# Altium schematic sheet MCU_PWR.SchDoc(MCU_PWR)
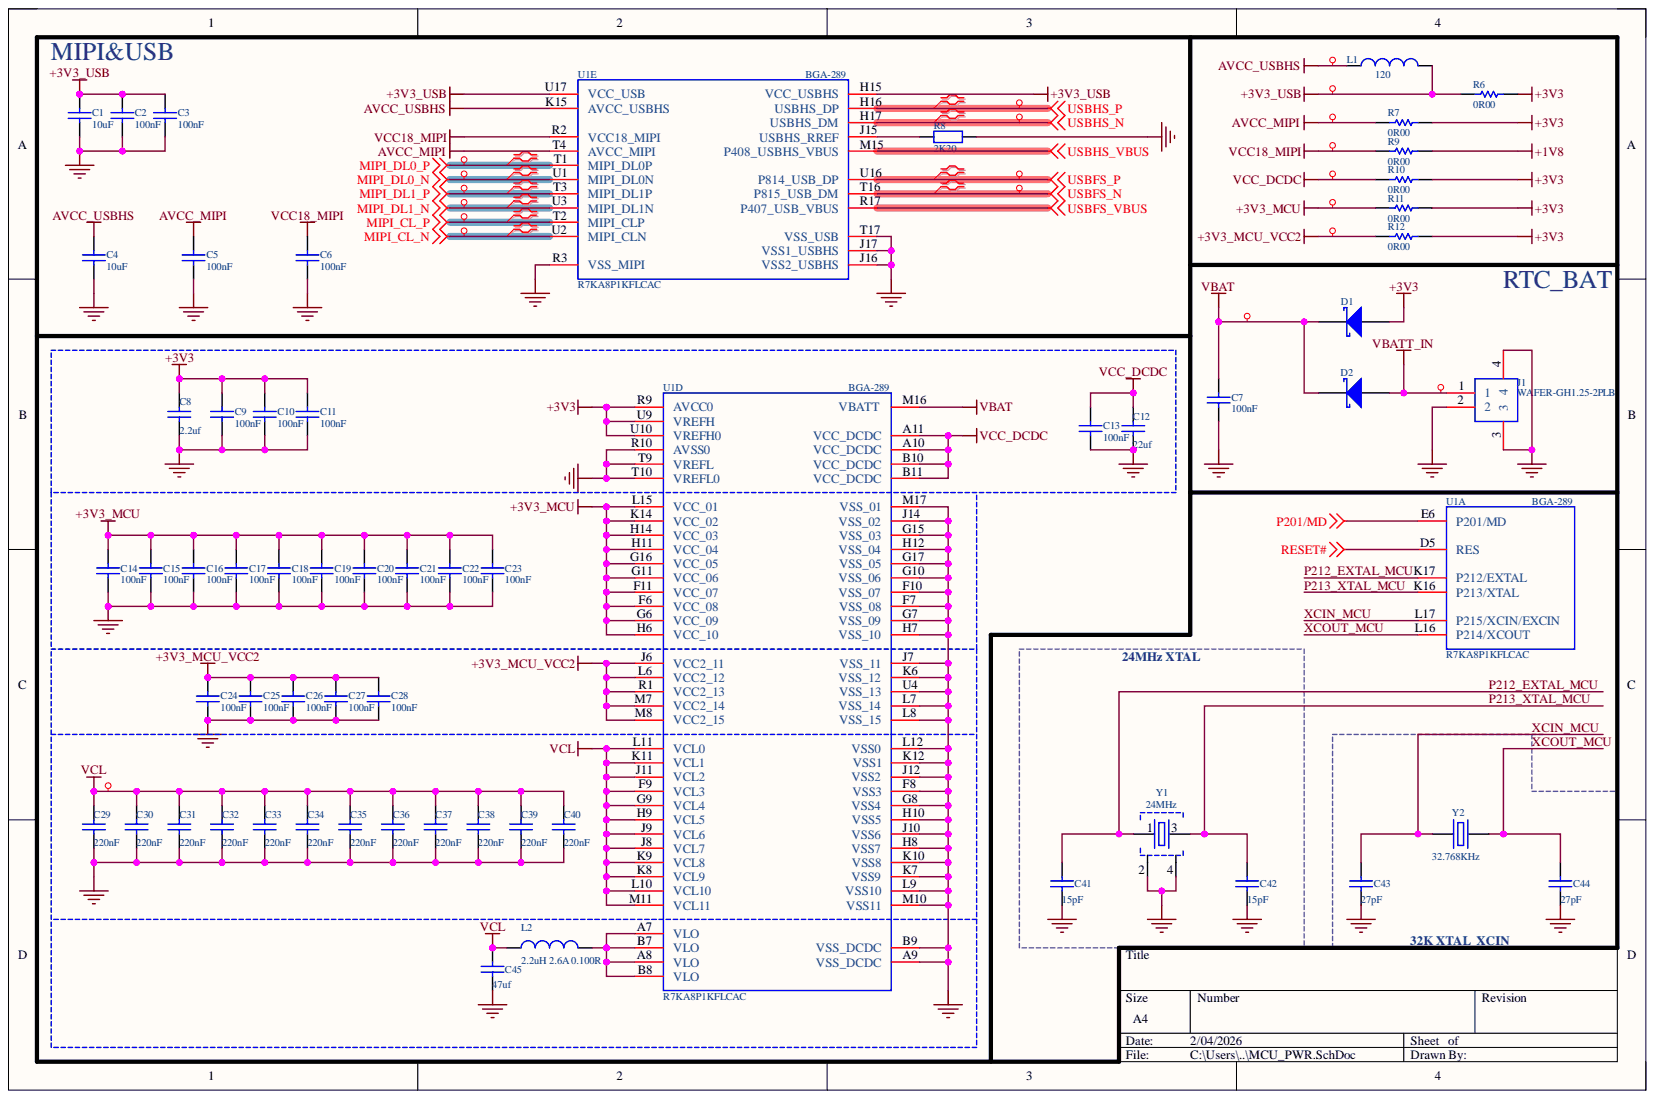
[diagram p0: MCU_PWR.SchDoc]

## Parts
C1  10uF  [C0603]
C2  100nF  [C0201]
C3  100nF  [C0201]
C4  10uF  [C0603]
C5  100nF  [C0201]
C6  100nF  [C0201]
C7  100nF  [C0201]
C8  2.2uf  [C0402]
C9  100nF  [C0201]
C10  100nF  [C0201]
C11  100nF  [C0201]
C12  22uf  [C0805]
C13  100nF  [C0201]
C14  100nF  [C0201]
C15  100nF  [C0201]
C16  100nF  [C0201]
C17  100nF  [C0201]
C18  100nF  [C0201]
C19  100nF  [C0201]
C20  100nF  [C0201]
C21  100nF  [C0201]
C22  100nF  [C0201]
C23  100nF  [C0201]
C24  100nF  [C0201]
C25  100nF  [C0201]
C26  100nF  [C0201]
C27  100nF  [C0201]
C28  100nF  [C0201]
C29  220nF  [C0201]
C30  220nF  [C0201]
C31  220nF  [C0201]
C32  220nF  [C0201]
C33  220nF  [C0201]
C34  220nF  [C0201]
C35  220nF  [C0201]
C36  220nF  [C0201]
C37  220nF  [C0201]
C38  220nF  [C0201]
C39  220nF  [C0201]
C40  220nF  [C0201]
C41  15pF  [C0201]
C42  15pF  [C0201]
C43  27pF  [C0201]
C44  27pF  [C0201]
C45  47uf  [C0805]
D1  B5819WS  [SOD-323_L1.7-W1.3-LS2.5-RD-1]  (value not drawn)
D2  B5819WS  [SOD-323_L1.7-W1.3-LS2.5-RD-1]  (value not drawn)
J1  WAFER-GH1.25-2PLB  [CONN-TH_2P-P1.25_XUNPU_WAFER-GH1.25-2PLB]
L1  IND-100020-01  [FB_0402]  (value not drawn)
L2  value 2.2uH 2.6A 0.100R  [IND_TDK_VLS252010HBX-1]  (hidden comment: Inductor)
R6  Resistance: 0R00  [R0805]  (hidden comment: 0805 0R)
R7  Resistance: 0R00  [R0805]  (hidden comment: 0805 0R)
R8  2K20  [R0402]
R9  Resistance: 0R00  [R0805]  (hidden comment: 0805 0R)
R10  Resistance: 0R00  [R0805]  (hidden comment: 0805 0R)
R11  Resistance: 0R00  [R0805]  (hidden comment: 0805 0R)
R12  Resistance: 0R00  [R0805]  (hidden comment: 0805 0R)
U1  Manufacturer Part Number 1: R7KA8P1KFLCAC  Package: BGA-289  [RENESAS_P289F1-65-EAT_BGA-289]  (hidden comment: ICN-100026-01)  (parts A,D,E)
Y1  value 24MHz  [SMD 2016-4P]  (hidden comment: XL7EL89CMI-111YLC-24M)
Y2  Frequency: 32.768KHz  [SMD 2012-2P]  (hidden comment: XKHEL89CGI-112YLC-32.768K)

## Nets
+1V8: R9.2
+3V3: C8.2 C9.2 C10.2 C11.2 D1.2 R6.2 R7.2 R10.2 R11.2 R12.2 U1.R9(AVCC0) U1.U9(VREFH) U1.U10(VREFH0)
+3V3_MCU: C14.2 C15.2 C16.2 C17.2 C18.2 C19.2 C20.2 C21.2 C22.2 C23.2 R11.1 U1.F6(VCC_08) U1.F11(VCC_07) U1.G6(VCC_09) U1.G11(VCC_06) U1.G16(VCC_05) U1.H6(VCC_10) U1.H11(VCC_04) U1.H14(VCC_03) U1.K14(VCC_02) U1.L15(VCC_01)
+3V3_MCU_VCC2: C24.2 C25.2 C26.2 C27.2 C28.2 R12.1 U1.J6(VCC2_11) U1.L6(VCC2_12) U1.M7(VCC2_14) U1.M8(VCC2_15) U1.R1(VCC2_13)
+3V3_USB: C1.2 C2.2 C3.2 L1.2 R6.1 U1.H15(VCC_USBHS) U1.U17(VCC_USB)
AVCC_MIPI: C5.2 R7.1 U1.T4(AVCC_MIPI)
AVCC_USBHS: C4.2 L1.1 U1.K15(AVCC_USBHS)
GND: C1.1 C2.1 C3.1 C4.1 C5.1 C6.1 C7.1 C8.1 C9.1 C10.1 C11.1 C12.1 C13.1 C14.1 C15.1 C16.1 C17.1 C18.1 C19.1 C20.1 C21.1 C22.1 C23.1 C24.1 C25.1 C26.1 C27.1 C28.1 C29.1 C30.1 C31.1 C32.1 C33.1 C34.1 C35.1 C36.1 C37.1 C38.1 C39.1 C40.1 C41.1 C42.1 C43.1 C44.1 C45.1 J1.2 J1.3 J1.4 R8.1 U1.A9(VSS_DCDC) U1.B9(VSS_DCDC) U1.F7(VSS_08) U1.F8(VSS3) U1.F10(VSS_07) U1.G7(VSS_09) U1.G8(VSS4) U1.G10(VSS_06) U1.G15(VSS_03) U1.G17(VSS_05) U1.H7(VSS_10) U1.H8(VSS7) U1.H10(VSS5) U1.H12(VSS_04) U1.J7(VSS_11) U1.J10(VSS6) U1.J12(VSS2) U1.J14(VSS_02) U1.J16(VSS2_USBHS) U1.J17(VSS1_USBHS) U1.K6(VSS_12) U1.K7(VSS9) U1.K10(VSS8) U1.K12(VSS1) U1.L7(VSS_14) U1.L8(VSS_15) U1.L9(VSS10) U1.L12(VSS0) U1.M10(VSS11) U1.M17(VSS_01) U1.R3(VSS_MIPI) U1.R10(AVSS0) U1.T9(VREFL) U1.T10(VREFL0) U1.T17(VSS_USB) U1.U4(VSS_13) Y1.2 Y1.4
MIPI_CL_N: U1.U2(MIPI_CLN)
MIPI_CL_P: U1.T2(MIPI_CLP)
MIPI_DL0_N: U1.U1(MIPI_DL0N)
MIPI_DL0_P: U1.T1(MIPI_DL0P)
MIPI_DL1_N: U1.U3(MIPI_DL1N)
MIPI_DL1_P: U1.T3(MIPI_DL1P)
P201/MD: U1.E6(P201/MD)
RESET#: U1.D5(RES)
USBFS_N: U1.T16(P815_USB_DM)
USBFS_P: U1.U16(P814_USB_DP)
USBFS_VBUS: U1.R17(P407_USB_VBUS)
USBHS_N: U1.H17(USBHS_DM)
USBHS_P: U1.H16(USBHS_DP)
USBHS_VBUS: U1.M15(P408_USBHS_VBUS)
VBAT: C7.2 D1.1 D2.1 U1.M16(VBATT)
VBATT_IN: D2.2 J1.1
VCC18_MIPI: C6.2 R9.1 U1.R2(VCC18_MIPI)
VCC_DCDC: C12.2 C13.2 R10.1 U1.A10(VCC_DCDC) U1.A11(VCC_DCDC) U1.B10(VCC_DCDC) U1.B11(VCC_DCDC)
VCL: C29.2 C30.2 C31.2 C32.2 C33.2 C34.2 C35.2 C36.2 C37.2 C38.2 C39.2 C40.2 C45.2 L2.1 U1.F9(VCL3) U1.G9(VCL4) U1.H9(VCL5) U1.J8(VCL7) U1.J9(VCL6) U1.J11(VCL2) U1.K8(VCL9) U1.K9(VCL8) U1.K11(VCL1) U1.L10(VCL10) U1.L11(VCL0) U1.M11(VCL11)
P212_EXTAL_MCU: C41.2 U1.K17(P212/EXTAL) Y1.1
P213_XTAL_MCU: C42.2 U1.K16(P213/XTAL) Y1.3
XCIN_MCU: C43.2 U1.L17(P215/XCIN/EXCIN) Y2.1
XCOUT_MCU: C44.2 U1.L16(P214/XCOUT) Y2.2
NetL2_2: L2.2 U1.A7(VLO) U1.A8(VLO) U1.B7(VLO) U1.B8(VLO)
NetR8_2: R8.2 U1.J15(USBHS_RREF)

## Library data of the parts
C1 C4 = CAP-000073-01: GRM188R61E106KA73D，0603，10uF ±20% 10V; Manufacturer: muRata; Manufacturer Part Number 1: CL05A106MQ5NUNC; Dialectric: X5R; Notes: ANY EQUIVALENT; Capacitance: 10uF; ClassName: MCU; Component Kind: Standard; ComponentLink1Description: New link; ComponentLink1URL: https://item.szlcsc.com/78127.html?fromZone=s_s__%252210UF%25200402%2522&spm=sc.gb.xh4.zy.n___sc.gb.hd.ss&lcsc_vid=RwBfVQZSEwJZV1NUEVEPX1cHQQNZXgUCFFhXBVwEFFcxVlNST1VeVFdVRlRXVDsOAxUeFF5JWBYZEEoVDQ0NFAdIFA4DSA%3D%3D; Library Name: RA8P1.SCHLIB; Manufacturer 1: muRata; Mfg: muRata; Mfg P/N: CL05A106MQ5NUNC; Mfg Part Number: CL05A106MQ5NUNC; Package: 0402; PN: CL05A106MQ5NUNC; Supplier 1: Digi-Key; Supplier Part Number 1: 1276-1451-1-ND; Tolerance: 20%; Voltage: 10V
C2 C3 C5-C7 C9-C11 C13-C28 = CAP-000030-01: 0201,100nF ±10% 16V，X7R; Legacy Part Number: 151-10004022; Manufacturer: muRata; Manufacturer Part Number 1: GRM033Z71C104KE14D; Dialectric: X7R; Notes: ANY EQUIVALENT; Voltage: 16V; Capacitance: 100nF; ClassName: MCU; Component Kind: Standard; ComponentLink1Description: New link; ComponentLink1URL: https://item.szlcsc.com/698285.html?fromZone=l_c__%2522catalog%2522&spm=sc.cid.xh3.zy.n___sc.gb.xh1.zy.l&lcsc_vid=FgdZAQZURgVfVlRQQQNbBAcFQVZYX1NeQ1BYX10ARFkxVlNSQ1FZUVReQVdaUjsOAxUeFF5JWAgaAglIBBsCBBcFWQIBCks%3D; Library Name: RA8P1.SCHLIB; Manufacturer 1: muRata; Manufacturer 3: AVX; Manufacturer Part Number 3: 0402YC104KAT2A; Mfg: muRata; Mfg P/N: GRM033Z71C104KE14D; Mfg Part Number: GRM033Z71C104KE14D; Package: 0402; PN: GRM033Z71C104KE14D; Supplier 1: Arrow Electronics; Supplier 2: Digi-Key; Supplier 3: Newark; Supplier 4: Mouser; Supplier Part Number 1: CC0402KRX7R8BB104; Supplier Part Number 2: 311-2077-1-ND; Supplier Part Number 3: 40AJ6370; Supplier Part Number 4: 603-CC402KRX7R8BB104; Tolerance: 10%
C8 = CAP-000067-01: 0402，2.2uF ±10% 10V，X7R，GRM033R61A225ME47D; Manufacturer: muRata; Manufacturer Part Number 1: GRM155Z71A225KE01D; Dialectric: X7R; Notes: ANY EQUIVALENT; Voltage: 10V; Capacitance: 2.2uF; ClassName: MCU; Component Kind: Standard; ComponentLink1Description: New link; ComponentLink1URL: https://item.szlcsc.com/3468099.html?fromZone=l_c__%2522catalog%2522&spm=sc.cid.xh2.zy.n___sc.gb.xh1.zy.l&lcsc_vid=FgdZAQZURgVfVlRQQQNbBAcFQVZYX1NeQ1BYX10ARFkxVlNSQ1FZUVReQVdaUjsOAxUeFF5JWAgaAglIBBsCBBcFWQIBCks%3D; Library Name: RA8P1.SCHLIB; Manufacturer 1: muRata; Manufacturer 2: Samsung; Manufacturer Part Number 2: CL05A225MP5NNNC; Mfg: muRata; Mfg P/N: GRM155Z71A225KE01D; Mfg Part Number: GRM155Z71A225KE01D; Package: 0402; Tolerance: 10%
C12 = CAP-100141-01: GRM21BZ71A226ME15L,0805,22uF ±20% 10V，X7R; Manufacturer: Murata; Dialectric: X7R; Notes: ANY EQUIVALENT; Tolerance: 10%; Voltage: 6.3V; Capacitance: 22uF; ClassName: MCU; Component Kind: Standard; ComponentLink1Description: New link; ComponentLink1URL: https://item.szlcsc.com/973892.html?fromZone=s_s__%252222uf%25200805%2522&spm=sc.gbn.xh11.zy.n&lcsc_vid=RwBfVQZSEwJZV1NUEVEPX1cHQQNZXgUCFFhXBVwEFFcxVlNSTlRfX11QT1NaUjsOAxUeFF5JWBIBSRccGwIdBEoFGAxBAAgJFQACSQwSGg0%3D; Library Name: RA8P1.SCHLIB; Manufacturer 1: Murata; Manufacturer Part Number 1: GRM21BZ71A226ME15L; Mfg: Murata; Mfg P/N: GRM21BZ71A226ME15L; Mfg Part Number: GRM21BZ71A226ME15L; Package: 1206; PN: GRM21BZ71A226ME15L; Supplier 1: Arrow Electronics; Supplier 2: Newark; Supplier 3: Mouser; Supplier 4: Digi-Key; Supplier Part Number 1: GRM31CR70J226KE19L; Supplier Part Number 2: 64AJ4427; Supplier Part Number 3: 81-GRM31CR70J226KE9L; Supplier Part Number 4: 490-6515-1-ND
C29-C40 = CAP-100140-01: 0201,220nF ±10% 16V,X5R; Manufacturer: muRata; Manufacturer Part Number 1: C1005X7R1C224K050BC; Notes: ANY EQUIVALENT; Capacitance: 220nF; ClassName: MCU; Component Kind: Standard; ComponentLink1Description: New link; ComponentLink1URL: https://item.szlcsc.com/172855.html?fromZone=l_c__%2522catalog%2522&spm=sc.cid.xh1.zy.n___sc.gb.xh1.zy.l&lcsc_vid=FgdZAQZURgVfVlRQQQNbBAcFQVZYX1NeQ1BYX10ARFkxVlNSQ1FZUVReQVdaUjsOAxUeFF5JWAgaAglIBBsCBBcFWQIBCks%3D; Dialectric: X5R; Library Name: RA8P1.SCHLIB; Manufacturer 1: muRata; Mfg: muRata; Mfg Part Number: C1005X7R1C224K050BC; Package: 0201; PN: C1005X7R1C224K050BC; Supplier 1: Arrow Electronics; Supplier 2: Newark; Supplier 3: Mouser; Supplier 4: Digi-Key; Supplier Part Number 1: C1005X7R1C224K050BC; Supplier Part Number 2: 05X9841; Supplier Part Number 3: 810-C1005X7R1C224K; Supplier Part Number 4: 445-5936-1-ND; Tolerance: 10%; Voltage: 16V
C41 C42 = CAP-000092-01: Ceramic Chip Capacitor 0402 15pF 25V; Manufacturer: TDK; Manufacturer Part Number 1: C0603C0G1E150J030BA; Dialectric: C0G; Notes: ANY EQUIVALENT; Tolerance: 5%; Capacitance: 15pF; ClassName: MCU; Component Kind: Standard; Library Name: RA8P1.SCHLIB; Manufacturer 1: TDK; Mfg: TDK; Mfg Part Number: C0603C0G1E150J030BA; Package: 0201; PN: C0603C0G1E150J030BA; Voltage: 25V
C43 C44 = CAP-100128-01: Ceramic Chip Capacitor 0402 27pF 50V，GRM0335C1H270JA01D; Legacy Part Number: 151-10007051; Manufacturer: Yageo; Manufacturer Part Number 1: CC0402JRNPO9BN270; Dialectric: C0G/NPO; Notes: ANY EQUIVALENT; Tolerance: 5%; Voltage: 50V; Capacitance: 27pF; ClassName: MCU; Component Kind: Standard; Library Name: RA8P1.SCHLIB; Manufacturer 1: Yageo; Mfg: Yageo; Mfg P/N: CC0402JRNPO9BN270; Mfg Part Number: CC0402JRNPO9BN270; Package: 0402; PN: CC0402JRNPO9BN270; Supplier 1: Future Electronics; Supplier 2: Digi-Key; Supplier 3: Mouser; Supplier 4: Farnell; Supplier Part Number 1: 9741513; Supplier Part Number 2: 311-1019-1-ND; Supplier Part Number 3: 603-CC402JRNPO9BN270; Supplier Part Number 4: 3369115
C45 = CAP-100142-01: 0805,47uF ±20% 6.3V,X5R,GRM21BR60J476ME15L; Manufacturer: Murata; Notes: MUST USE SPECIFIC; Capacitance: 47uF; ClassName: MCU; Component Kind: Standard; ComponentLink1Description: New link; ComponentLink1URL: https://item.szlcsc.com/78199.html?fromZone=s_s__%252247uf%25200805%2522&spm=sc.gbn.xh6.zy.n&lcsc_vid=RwBfVQZSEwJZV1NUEVEPX1cHQQNZXgUCFFhXBVwEFFcxVlNSTlRfX1xUTlJbUjsOAxUeFF5JWBIBSRccGwIdBEoFGAxBAAgJFQACSQwSGg0%3D; Dialectric: X5R; Library Name: RA8P1.SCHLIB; Manufacturer 1: Murata; Manufacturer Part Number 1: GRM21BR60J476ME15L; Mfg: Murata; Mfg P/N: GRM21BR60J476ME15L; Mfg Part Number: GRM21BR60J476ME15L; Package: 0603; PN: GRM21BR60J476ME15L; Supplier 1: Arrow Electronics; Supplier 2: Newark; Supplier 3: Mouser; Supplier 4: Digi-Key; Supplier Part Number 1: GRM32ER70J476KE20L; Supplier Part Number 2: 13T1230; Supplier Part Number 3: 81-GRM32ER70J476KE0L; Supplier Part Number 4: 490-5311-1-ND; Tolerance: 20%; Voltage: 6.3V
D1 D2 = B5819WS: Schottky Barrier Diode, 1A 40V, 0.60Vf @ 1A, 1mA max Ir; Manufacturer: Rohm; Manufacturer Part Number 1: RB168MM-40; Ifsm: 40A; Ir_max: 0.55uA; Ir_typ: 0.05uA; Value: RB168MM-40; ClassName: MCU; Component Kind: Standard; Io: 1A; Library Name: RA8P1.SCHLIB; Manufacturer 1: Rohm; Mfg: Rohm; Mfg P/N: RB168MM-40; Mfg Part Number: RB168MM-40; PN: RB168MM-40; Supplier 1: Avnet; Supplier 2: Digi-Key; Supplier 3: Future Electronics; Supplier 4: Mouser; Supplier Part Number 1: RB168MM-40TR; Supplier Part Number 2: RB168MM-40CT-ND; Supplier Part Number 3: 9087689; Supplier Part Number 4: 755-RB168MM-40TR; Vr: 40V
J1 = WAFER-GH1.25-2PLB: 立式; Manufacturer: XUNPU(讯普); Add into BOM: yes; Current Rating: 1A; Number of PINs Per Row: 2; Pins Structure: 1x2P; Pitch: 1.25mm; Plastic Material: LCP; Reference Series: GH; Supplementary Features: 辅助焊脚; Voltage Rating: 125V; X-Length of Bottom Edge on Board (Spacing Line): 5.75mm; Y-Width of Bottom Edge on Board: 4.95mm; Z-Height of the Board: 4.2mm; Color: 米色; Component Kind: Standard; Contact Material: 黄铜; Contact Plating: -; Convert to PCB: yes; Datasheet: https://atta.szlcsc.com/upload/public/pdf/source/20220527/44F824AAC8C2B617205E15955FF9C23D.pdf; Device: WAFER-GH1.25-2PLB; Flame Retardant Rating: -; JLCPCB Part Class: Extended Part; LCSC Part Name: 1x2P 间距:1.25mm 立贴 系列:GH; Library Name: RA8P1.SCHLIB; Manufacturer Part: WAFER-GH1.25-2PLB; Mounting Type: 立贴; Number of Pins: 2P; Number of Rows: 1; Operating Temperature: -25℃~+85℃; PCB3D: CONN-TH_2P-P1.25_XUNPU_WAFER-GH1.25-2PLB; Row Spacing: -; Supplier: LCSC; Supplier Footprint: SMD,P=1.25mm; Supplier Part: C3029368; Symbol: WAFER-GH1.25-2PLB
L1 = IND-100020-01: Chip Ferrite Bead 0402 120ohm 1.2A 25%; Manufacturer: TDK; Manufacturer Part Number 1: MPZ1005S121CT000; Notes: ANY EQUIVALENT; Resistance: 120; ClassName: MCU; Component Kind: Standard; Current: 1.2A; Library Name: RA8P1.SCHLIB; Manufacturer 1: TDK; Mfg: TDK; Mfg Part Number: MPZ1005S121CT000; Package: 0402; PN: MPZ1005S121CT000; Supplier 1: Avnet; Supplier 2: Mouser; Supplier 3: Digi-Key; Supplier Part Number 1: MPZ1005S121CT000; Supplier Part Number 2: 810-MPZ1005S121CT000; Supplier Part Number 3: 445-2981-1-ND; Tolerance: 25%
L2 = MCD-00240: FIXED IND 2.2UH 2.6A 100 mOHM 20% SMD; Manufacturer: TDK; Manufacturer_Part_Number: VLS252010HBX-2R2M-1; Provided_By: SUBCO; Value: 2.2uH 2.6A 0.100R; Component Kind: Standard; ComponentLink1Description: Datasheet; ComponentLink1URL: http://tunmcdsvn.tun.st.com/Component_Datasheet_Library/Trunk/Inductors/TDK_Inductor_VLS252010HBX-1; ECCN_EU: n/a; ECCN_US: n/a; Internal_Part_Number: MCD-00240; Library Name: RA8P1.SCHLIB; Part_Type: Inductor; Status: Released
R6 R7 R9-R12 = 0805 0R: Chip Resistor Thick Film 0805  0R00 1% 125mW，0805W8F0000T5E; Legacy Part Number: 150-00000004; Manufacturer: Yageo; Manufacturer Part Number 1: RC1206JR-070RL; Notes: ANY EQUIVALENT; Power: 1/4W; ClassName: MCU; Component Kind: Standard; Library Name: RA8P1.SCHLIB; Manufacturer 1: Yageo; Mfg: Yageo; Mfg Part Number: RC1206JR-070RL; Package: 1206; PN: RC1206JR-070RL; Supplier 1: Digi-Key; Supplier 2: Future Electronics; Supplier 3: Arrow Electronics; Supplier 4: Avnet; Supplier 5: Digi-Key; Supplier 6: Mouser; Supplier Part Number 1: P0.0ECT-ND; Supplier Part Number 2: 1414689; Supplier Part Number 3: RC1206JR-070RL; Supplier Part Number 4: RC1206JR-070RL; Supplier Part Number 5: 311-0.0ERCT-ND; Supplier Part Number 6: 603-RC1206JR-070RL; Tolerance: 1%
R8 = RES-000175-01: Chip Resistor Thick Film 0402 2K20 1% 1/10W; Manufacturer: Panasonic; Manufacturer Part Number 1: ERJ-2RKF2201X; Notes: ANY EQUIVALENT; ClassName: MCU; Component Kind: Standard; Library Name: RA8P1.SCHLIB; Manufacturer 1: Panasonic; Mfg Part Number: ERJ-2RKF2201X; Package: 0402; Power: 1/10W; Resistance: 2K20; Supplier 1: Digi-Key; Supplier Part Number 1: P2.20KLCT-ND; Tolerance: 1%
U1 = ICN-100026-01: Renesas RA8P1 32-bit MCU BGA-289 12x12mm 0.65mm pitch; Architecture: 32bits; Code Flash: 1MB; Max Clock Speed: 1GHz; Notes: MUST USE SPECIFIC ?? FREE ISSUE ??; SRAM: 2MB; ClassName: BGA_MCU; Component Kind: Standard; Library Name: RA8P1.SCHLIB; Manufacturer: Renesas; Manufacturer 1: Renesas; Mfg: Renesas; Mfg Part Number: R7KA8P1KFLCAC; PN: R7KA8P1KFLCAC
Y1 = XTL-100003-01: 24MHz ±10ppm 10pF; Legacy Part Number: 170-10007505; Manufacturer: Diodes Inc.; Manufacturer Part Number 1: FL2400022; Comment1: 24MHz 10ppmTol 10ppmStab 10pF 50oESR SMD3.2x2.5x0.8mm; Notes: MUST USE SPECIFIC; Subs Approved: ABM8X-101-24.000MHZ-T; ClassName: MCU; Component Kind: Standard; ComponentLink1Description: New link; ComponentLink1URL: https://item.szlcsc.com/71681.html?fromZone=l_b__%2522brand%2522&spm=sc.bid.xh6.zy.t&lcsc_vid=FANcVFBQFQMNX1cFFAdZVVZXEgdeAgdWFlQNUVAHT1ExVlNSRFhXUVRRT1NYVjsOAxUeFF5JWA0HFBBIBBsCBBcFWQIBCksEBQAAAzsWFgYLSFZeQE8GEwkK; Library Name: RA8P1.SCHLIB; Manufacturer 1: Diodes Inc.; Mfg: Diodes Inc.; Mfg P/N: FL2400022; Mfg Part Number: FL2400022; Supplier 1: Digi-Key; Supplier Part Number 1: FL2400022CT-ND
Y2 = XTL-000006-01: 32.768kHz ±20ppm 12.5pF; Manufacturer: Abracon; Manufacturer Part Number 1: ABS07-32.768KHZ-T; Freq Stability: +/- 20ppm; Notes: MUST USE SPECIFIC; ClassName: MCU; Component Kind: Standard; ComponentLink1Description: New link; ComponentLink1URL: https://item.szlcsc.com/8579117.html?fromZone=l_b__%2522brand%2522&spm=sc.bid.xh1.zy.n&lcsc_vid=E1YIU1FRQVIMBVNRRVhcBAYDQwJbUQICQFgLBVMDElIxVlNSQ1lWU1dRQVlWVTsOAxUeFF5JWA0HFBBIBBsCBBcFWQIBCksEBQAAAzsWFgYLSFZeQE8GEwkK; Library Name: RA8P1.SCHLIB; Load Capacitance: 12.5pF; Manufacturer 1: Abracon; Mfg Part Number: ABS07-32.768KHZ-T; Supplier 1: Digi-Key; Supplier Part Number 1: 535-9542-1-ND
